# Altium schematic sheet InputConnector.SchDoc
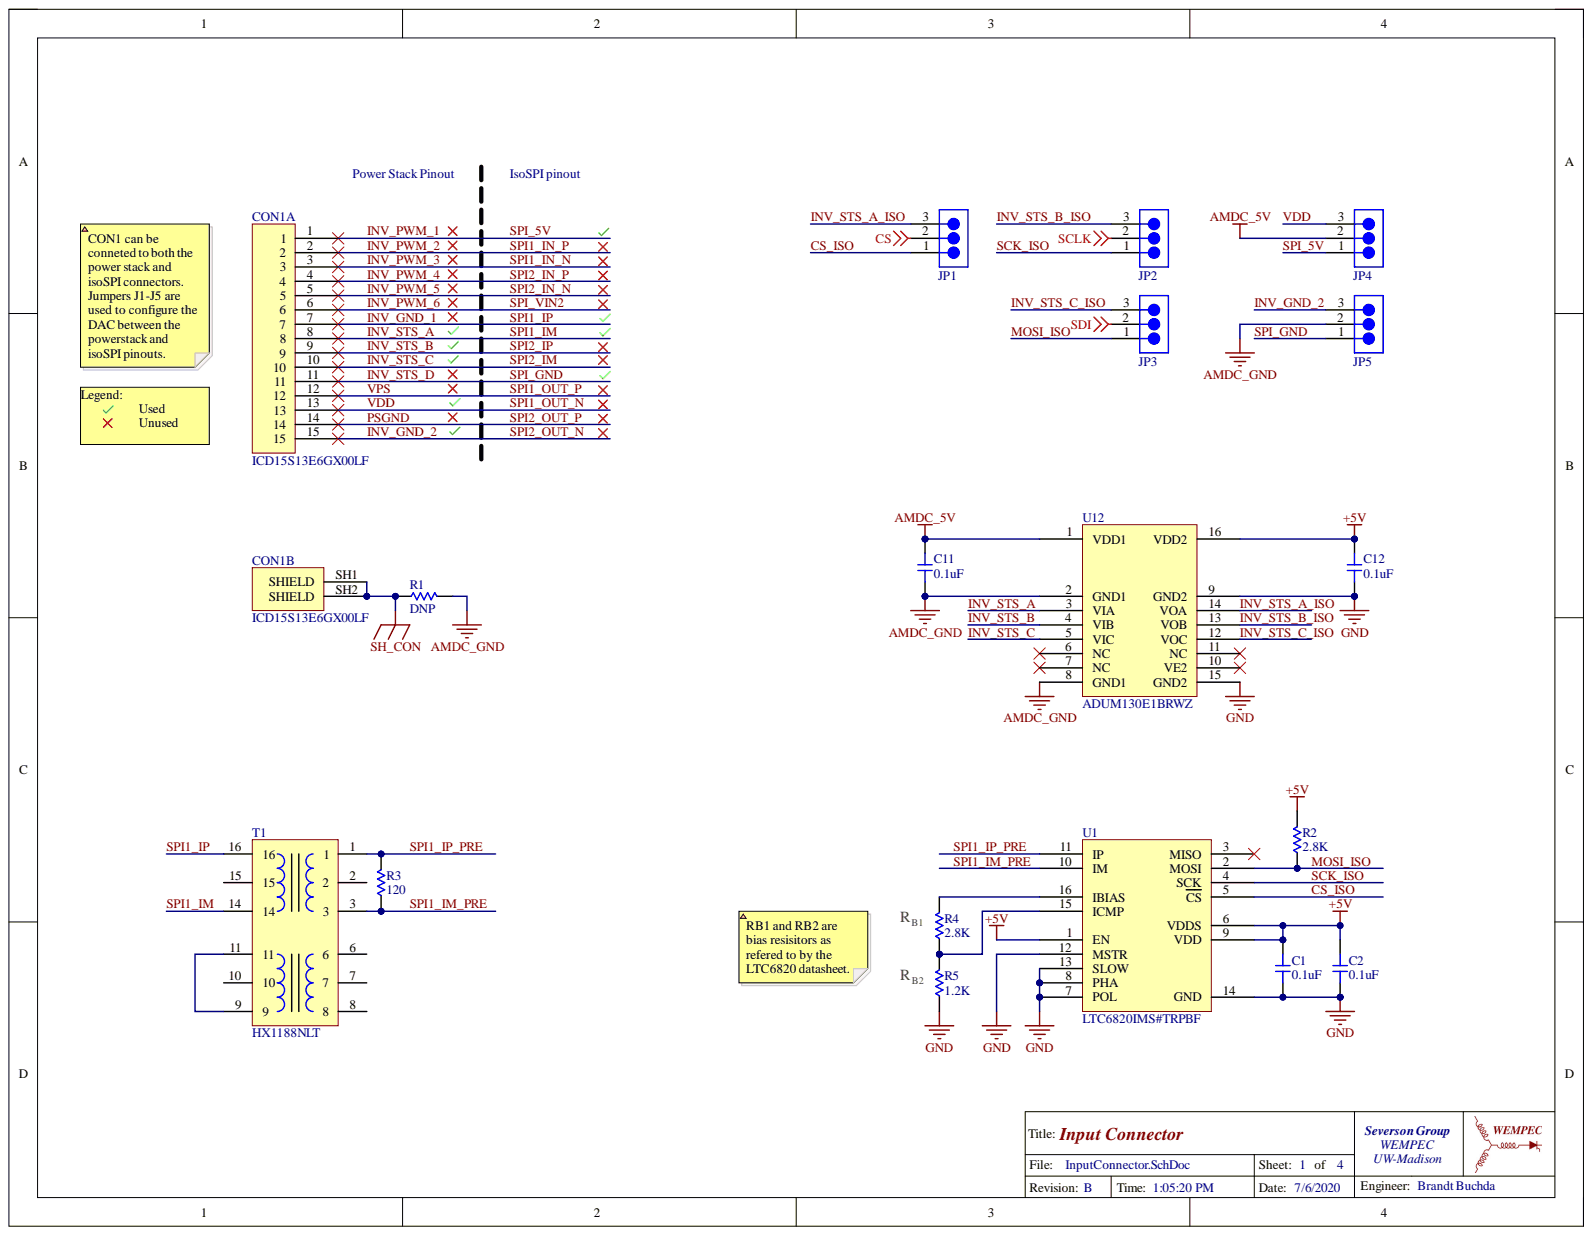
[diagram p0: InputConnector.SchDoc]

## Parts
C1  value 0.1uF  [0603-CAP]  (hidden comment: CC0603KRX7R8BB104)
C2  value 0.1uF  [0603-CAP]  (hidden comment: CC0603KRX7R8BB104)
C11  value 0.1uF  [0603-CAP]  (hidden comment: CC0603KRX7R8BB104)
C12  value 0.1uF  [0603-CAP]  (hidden comment: CC0603KRX7R8BB104)
CON1  ICD15S13E6GX00LF  [ICD15S13E6GX00LF]  (parts A,B)
JP1  TSW-103-07-F-S  [PIN_HEADER_1X3]  (value not drawn)
JP2  TSW-103-07-F-S  [PIN_HEADER_1X3]  (value not drawn)
JP3  TSW-103-07-F-S  [PIN_HEADER_1X3]  (value not drawn)
JP4  TSW-103-07-F-S  [PIN_HEADER_1X3]  (value not drawn)
JP5  TSW-103-07-F-S  [PIN_HEADER_1X3]  (value not drawn)
R1  value DNP  [0603-RES]  (hidden comment: Resistor)
R2  value 2.8K  [0603-RES]  (hidden comment: RC0603FR-072K8L)
R3  value 120  [0603-RES]  (hidden comment: RC0603FR-07120RL)
R4  value 2.8K  [0603-RES]  (hidden comment: RC0603FR-072K8L)
R5  value 1.2K  [0603-RES]  (hidden comment: RC0603FR-071K2L)
T1  HX1188NLT  [HX1188NLT]
U1  LTC6820IMS#TRPBF  [TSSOP50P490X110-16N]
U12  ADUM130E1BRWZ  [SOIC127P1030X265-16N]

## Nets
+5V: C1.2 C2.2 C12.2 R2.2 U1.1(EN) U1.6(VDDS) U1.9(VDD) U12.16(VDD2)
AMDC_5V: C11.2 JP4.2 U12.1(VDD1)
AMDC_GND: C11.1 JP5.2 R1.2 U12.2(GND1) U12.8(GND1)
CS: JP1.2
GND: C1.1 C2.1 C12.1 R5.1 U1.7(POL) U1.8(PHA) U1.12(MSTR) U1.13(SLOW) U1.14(GND) U12.9(GND2) U12.15(GND2)
SCLK: JP2.2
SDI: JP3.2
SH_CON: CON1.SH1(SHIELD) CON1.SH2(SHIELD) R1.1
CS_ISO: JP1.1 U1.5(CS)
INV_GND_1: CON1.7 T1.16
INV_GND_2: CON1.15 JP5.3
INV_PWM_1: CON1.1 JP4.1
INV_PWM_2: CON1.2
INV_PWM_3: CON1.3
INV_PWM_4: CON1.4
INV_PWM_5: CON1.5
INV_PWM_6: CON1.6
INV_STS_A: CON1.8 T1.14 U12.3(VIA)
INV_STS_A_ISO: JP1.3 U12.14(VOA)
INV_STS_B: CON1.9 U12.4(VIB)
INV_STS_B_ISO: JP2.3 U12.13(VOB)
INV_STS_C: CON1.10 U12.5(VIC)
INV_STS_C_ISO: JP3.3 U12.12(VOC)
INV_STS_D: CON1.11 JP5.1
MOSI_ISO: JP3.1 R2.1 U1.2(MOSI)
PSGND: CON1.14
SCK_ISO: JP2.1 U1.4(SCK)
SPI1_IM_PRE: R3.1 T1.3 U1.10(IM)
SPI1_IP_PRE: R3.2 T1.1 U1.11(IP)
SPI1_OUT_N: CON1.13 JP4.3
SPI1_OUT_P: CON1.12
NetR4_1: R4.1 R5.2 U1.15(ICMP)
NetR4_2: R4.2 U1.16(IBIAS)
NetT1_9: T1.9 T1.11

Not connected: T1 2 6 7 8 10 15; U1 3(MISO); U12 6(NC) 7(NC) 10(VE2) 11(NC)

## Library data of the parts
C1 C2 C11 C12 = Capacitor 0.1uF: 0.1µF ±10% 25V Ceramic Capacitor X7R 0603 (1608 Metric); Component Kind: Standard; Simulation: CAP; Supplier 1: Digi-Key; Supplier Part Number 1: 311-1341-1-ND; Library Name: DAC.SchLib
CON1 = ICD15S13E6GX00LF: 15 Position D-Sub, High Density Receptacle, Female Sockets Connector; Component Kind: Standard; Supplier 1: Digi-Key; Supplier Part Number 1: 609-2801-ND; Library Name: DAC.SchLib
JP1-JP5 = TSW-103-07-F-S: Connector Header Through Hole 3 position 0.100" (2.54mm); Component Kind: Standard; Supplier 1: Digi-Key; Supplier Part Number 1: SAM8995-ND; Library Name: DAC.SchLib
R1 = Resistor: DNP; Component Kind: Standard; Simulation: RES; Supplier 1: Digi-Key; Library Name: DAC.SchLib
R2 R4 = Resistor 2.8K: 2.8 kOhms ±1% 0.1W, 1/10W Chip Resistor 0603 (1608 Metric) Moisture Resistant Thick Film; Component Kind: Standard; Simulation: RES; Supplier 1: Digi-Key; Supplier Part Number 1: 311-2.80KHRCT-ND; Library Name: DAC.SchLib
R3 = Resistor 120: 120 Ohms ±1% 0.1W, 1/10W Chip Resistor 0603 (1608 Metric) Moisture Resistant Thick Film; Component Kind: Standard; Simulation: RES; Supplier 1: Digi-Key; Supplier Part Number 1: 311-120HRCT-ND; Library Name: DAC.SchLib
R5 = Resistor 1.2K: 1.2 kOhms ±1% 0.1W, 1/10W Chip Resistor 0603 (1608 Metric) Moisture Resistant Thick Film; Component Kind: Standard; Simulation: RES; Supplier 1: Digi-Key; Supplier Part Number 1: 311-1.20KHRCT-ND; Library Name: DAC.SchLib
T1 = HX1188NLT: XFRMR MAGNETIC 1PORT 1:1 10/100; Component Kind: Standard; Supplier 1: Digi-Key; Supplier Part Number 1: 553-1536-1-ND; Library Name: AMDC.SchLib
U1 = LTC6820IMS#TRPBF: IC INTERFACE SPECIALIZED 16MSOP; Component Kind: Standard; Supplier 1: Digi-Key; Supplier Part Number 1: LTC6820IMS#TRPBFCT-ND; Library Name: AMDC.SchLib
U12 = ADUM130E1BRWZ: General Purpose Digital Isolator 3750Vrms 3 Channel 150Mbps 75kV/µs CMTI 16-SOIC (0.295", 7.50mm Width); Component Kind: Standard; Supplier 1: Digi-Key; Supplier Part Number 1: ADUM130E1BRWZ-ND; Library Name: DAC.SchLib
